# Altium schematic sheet LoRa通信板.SchDoc(LoRa通信板)
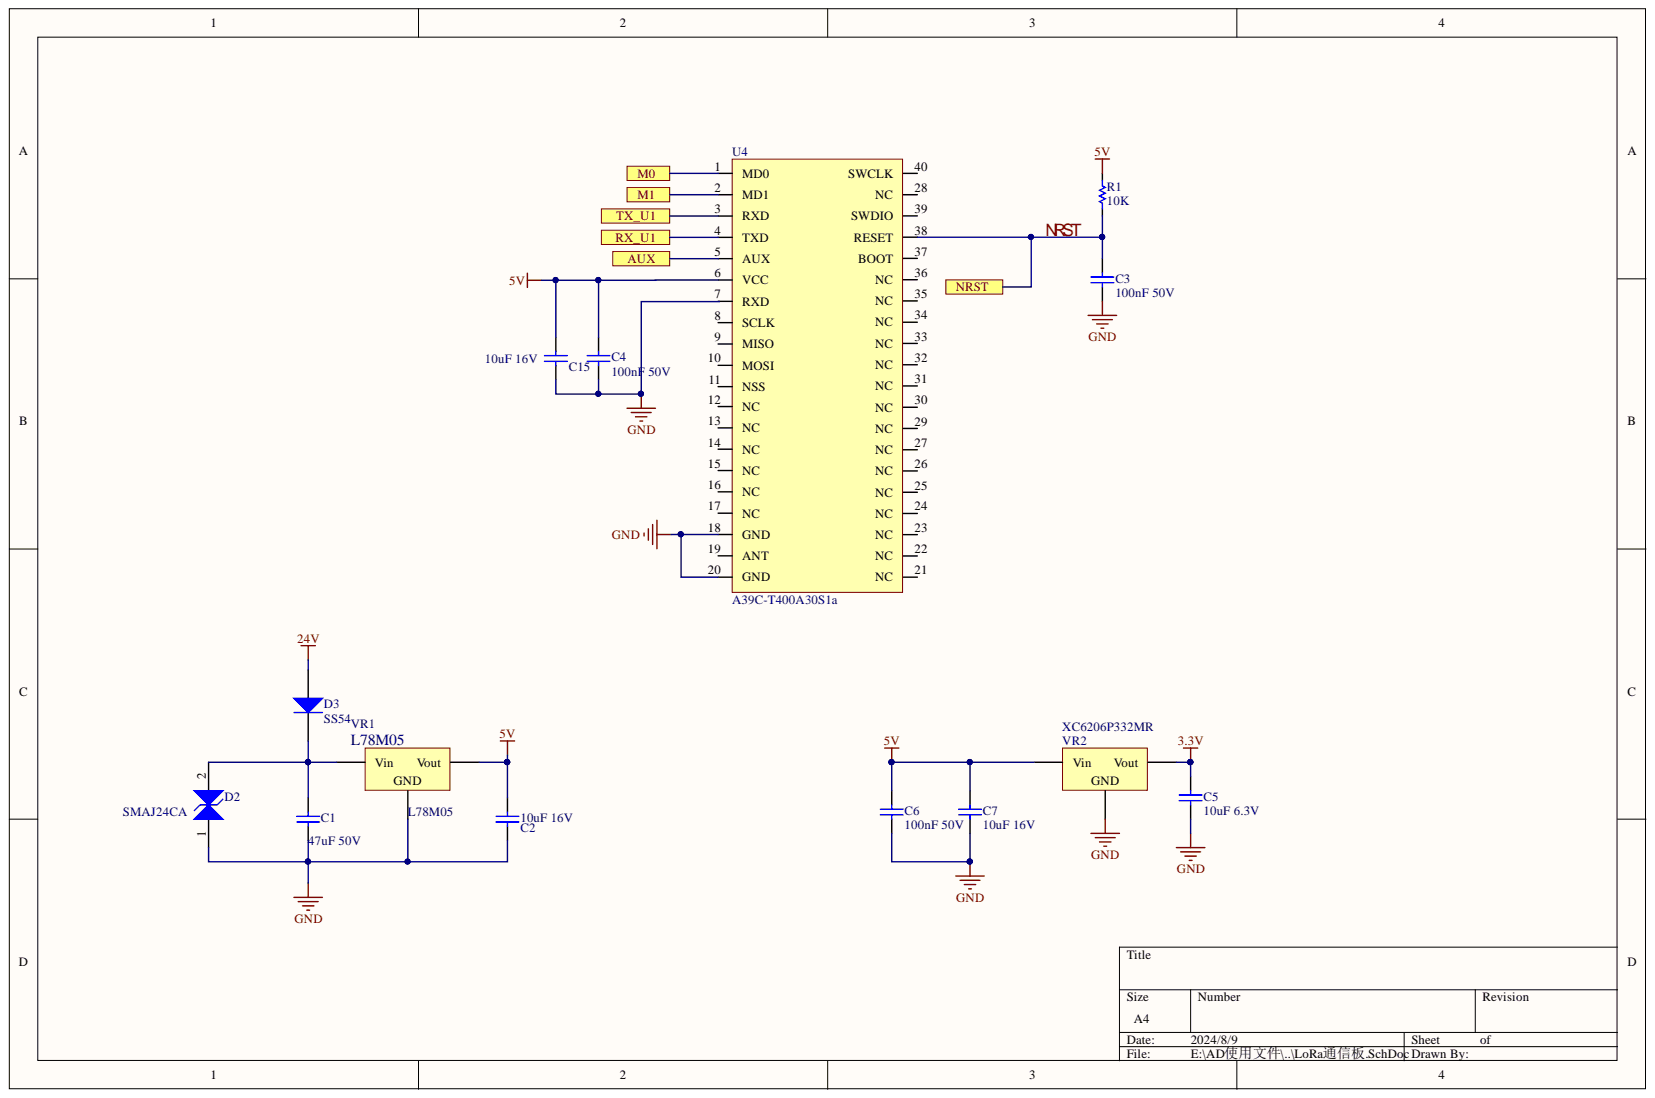
[diagram p0: LoRa通信板.SchDoc]

## Parts
C1  47uF 50V  [C 1812_M]
C2  10uF 16V  [0805_C]
C3  100nF 50V  [0603_C]
C4  100nF 50V  [0603_C]
C5  10uF 6.3V  [0603_C]
C6  100nF 50V  [0603_C]
C7  10uF 16V  [0805_C]
C15  10uF 16V  [0805_C]
D2  SMAJ24CA  [SMA(DO-214AC)_S1]
D3  SS54  [SMA(DO-214AC)_S1]
R1  10K  [0603_R]
U4  A39C-T400A30S1a  [A39C-T400A30S1a]
VR1  L78M05  [TO-252-2]
VR2  XC6206P332MR  [SOT-23-3L]

## Ports
AUX, M0, M1, NRST, RX_U1, TX_U1

## Nets
3.3V: C5.2 VR2.2
5V: C2.1 C4.2 C6.2 C7.2 C15.1 R1.1 U4.6(VCC) VR1.3 VR2.3
24V: D3.1
GND: C1.1 C2.2 C3.1 C4.1 C5.1 C6.1 C7.1 C15.2 D2.1 U4.18(GND) U4.20(GND) VR1.2 VR2.1
AUX: U4.5(AUX) ; port AUX
M0: U4.1(MD0) ; port M0
M1: U4.2(MD1) ; port M1
NRST: C3.2 R1.2 U4.38(RESET) ; port NRST
RX_U1: U4.4(TXD) ; port RX_U1
TX_U1: U4.3(RXD) ; port TX_U1
NetC1_2: C1.2 D2.2 D3.2 VR1.1

Not connected: U4 7(RXD) 8(SCLK) 9(MISO) 10(MOSI) 11(NSS) 12(NC) 13(NC) 14(NC) 15(NC) 16(NC) 17(NC) 19(ANT) 21(NC) 22(NC) 23(NC) 24(NC) 25(NC) 26(NC) 27(NC) 28(NC) 29(NC) 30(NC) 31(NC) 32(NC) 33(NC) 34(NC) 35(NC) 36(NC) 37(BOOT) 39(SWDIO) 40(SWCLK)

## Library data of the parts
C1 = 0805 22uF (226) 20% 25V: 贴片电容; SuppliersPartNumber: C45783; Supplier: LC; Package: 0805; Library Name: Cap.SchLib; ComponentLink1URL: http://www.szlcsc.com/product/details_46786.html; ComponentLink1Description: 供应商链接; Component Kind: Standard
C2 C15 = Cap: Capacitor; Value: 1uF; Simulation: CAP; Published: 8-Jun-2000; PackageReference: RAD-0.3; PackageDescription: Radial Cap, Thru-Hole; 2 Leads; 0.3 in Pin Spacing; LatestRevisionNote: Re-released for DXP Platform.; LatestRevisionDate: 17-Jul-2002; Component Kind: Standard; Publisher: Altium Limited
C3 C4 C6 = 0402 100nF (104) 10% 16V: 贴片电容; SuppliersPartNumber: C1525; Supplier: LC; Package: 0402; Library Name: Cap.SchLib; ComponentLink1URL: http://www.szlcsc.com/product/details_1877.html; ComponentLink1Description: 供应商链接; Component Kind: Standard
C5 C7 = 0402 10uF (106) 20% 6.3V: 贴片电容; SuppliersPartNumber: C15525; Supplier: LC; Package: 0402; Library Name: Cap.SchLib; ComponentLink1URL: http://www.szlcsc.com/product/details_16204.html; ComponentLink1Description: 供应商链接; Component Kind: Standard
D2 = SMB/DO-214AA P6SMB6.8CA: TVS; SuppliersPartNumber: C78395; Supplier: LC; Package: SMB/DO-214AA; Library Name: Diode.SchLib; ComponentLink1URL: http://www.szlcsc.com/product/details_79530.html; ComponentLink1Description: 供应商链接; Component Kind: Standard
D3 = SMA(DO-214AC) SS54: 二极管; SuppliersPartNumber: C22452; Supplier: LC; Package: SMA(DO-214AC); Library Name: Diode.SchLib; ComponentLink1URL: http://www.szlcsc.com/product/details_23178.html; ComponentLink1Description: 供应商链接; Component Kind: Standard
R1 = Res: Resistor; Value: 10R; Simulation: RESISTOR; Published: 8-Jun-2000; PackageReference: AXIAL-0.3; PackageDescription: Axial Device, Thru-Hole; 2 Leads; 0.3 in Pin Spacing; LatestRevisionNote: Re-released for DXP Platform.; LatestRevisionDate: 17-Jul-2002; Component Kind: Standard; Publisher: Altium Limited; Library Name: MAMA.SCHLIB
VR1 = SOT-23 XC6206P332MR_1: 低压差线性稳压(LDO); SuppliersPartNumber: C5446; Supplier: LC; Package: SOT-23; ComponentLink1URL: http://www.szlcsc.com/product/details_5896.html; ComponentLink1Description: 供应商链接; Component Kind: Standard; Library Name: LoRa通信板.SCHLIB
VR2 = SOT-23 XC6206P332MR: 低压差线性稳压(LDO); SuppliersPartNumber: C5446; Supplier: LC; Package: SOT-23; Library Name: LDO.SchLib; ComponentLink1URL: http://www.szlcsc.com/product/details_5896.html; ComponentLink1Description: 供应商链接; Component Kind: Standard
U4 = A39C-T400A30S1a: Component Kind: Standard; Library Name: LDGlib.SchLib
